# Altium schematic sheet LMcude-R_01.SchDoc(LMcude-R_01)
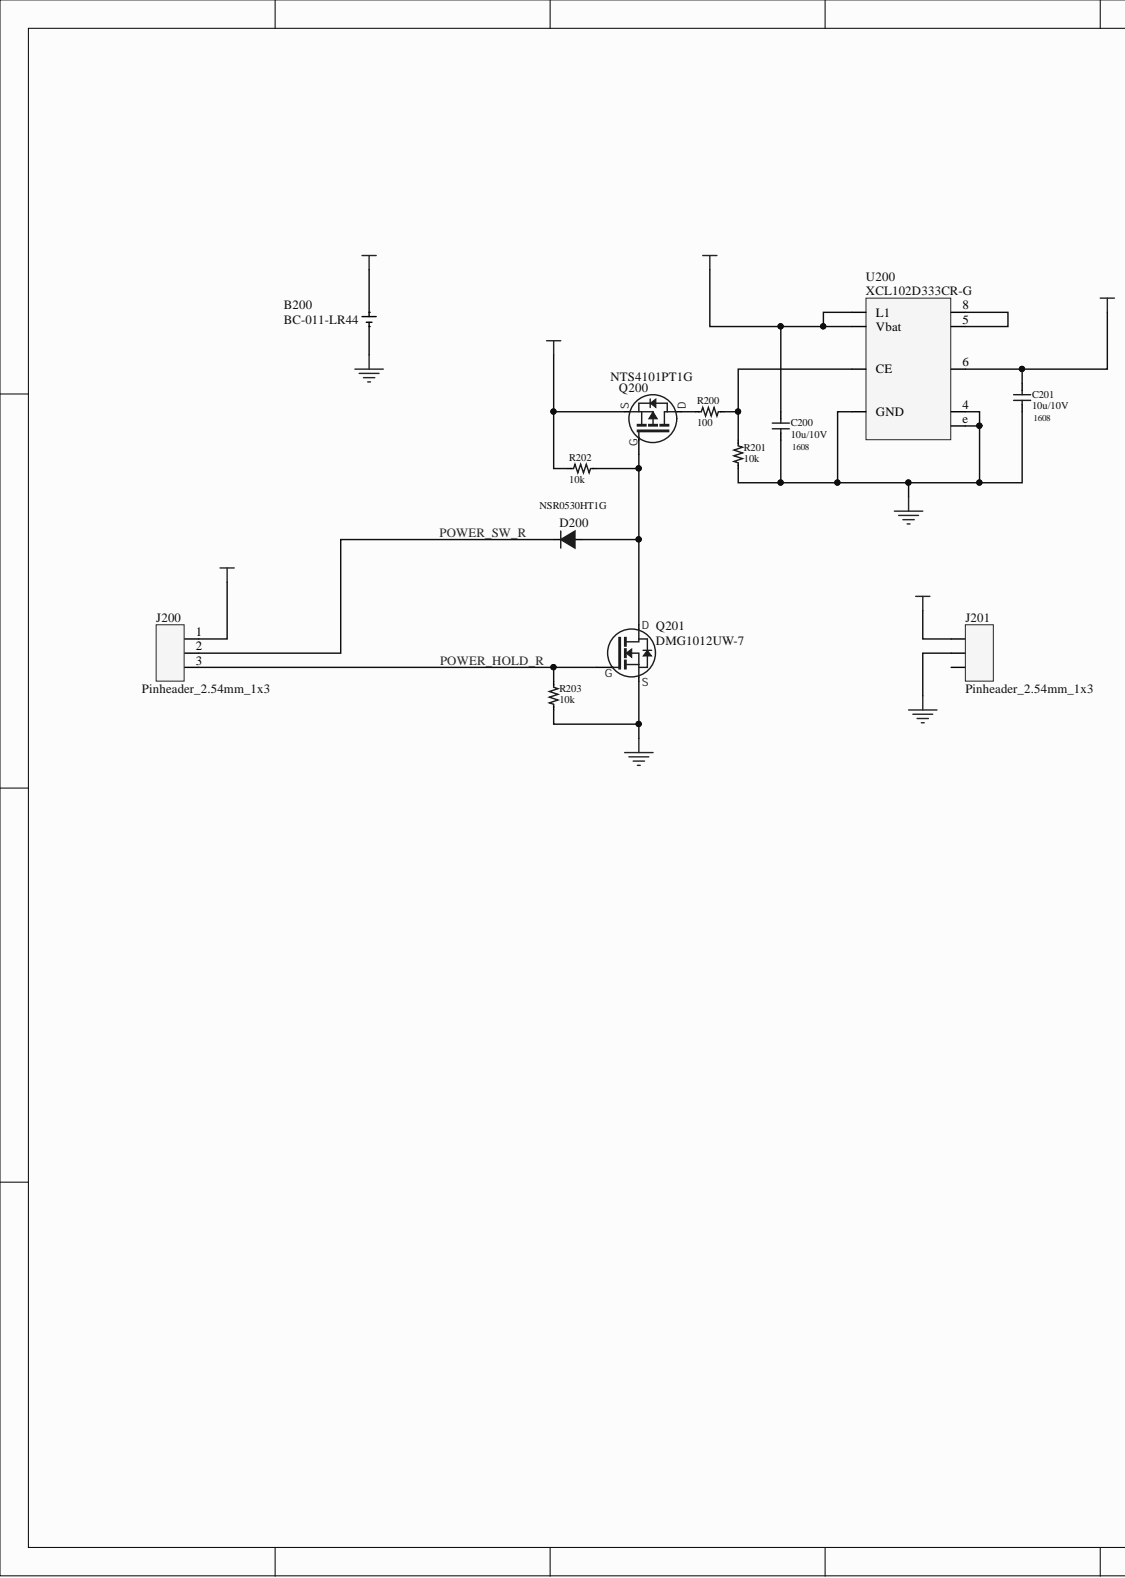
[diagram p0: LMcude-R_01.SchDoc, left]
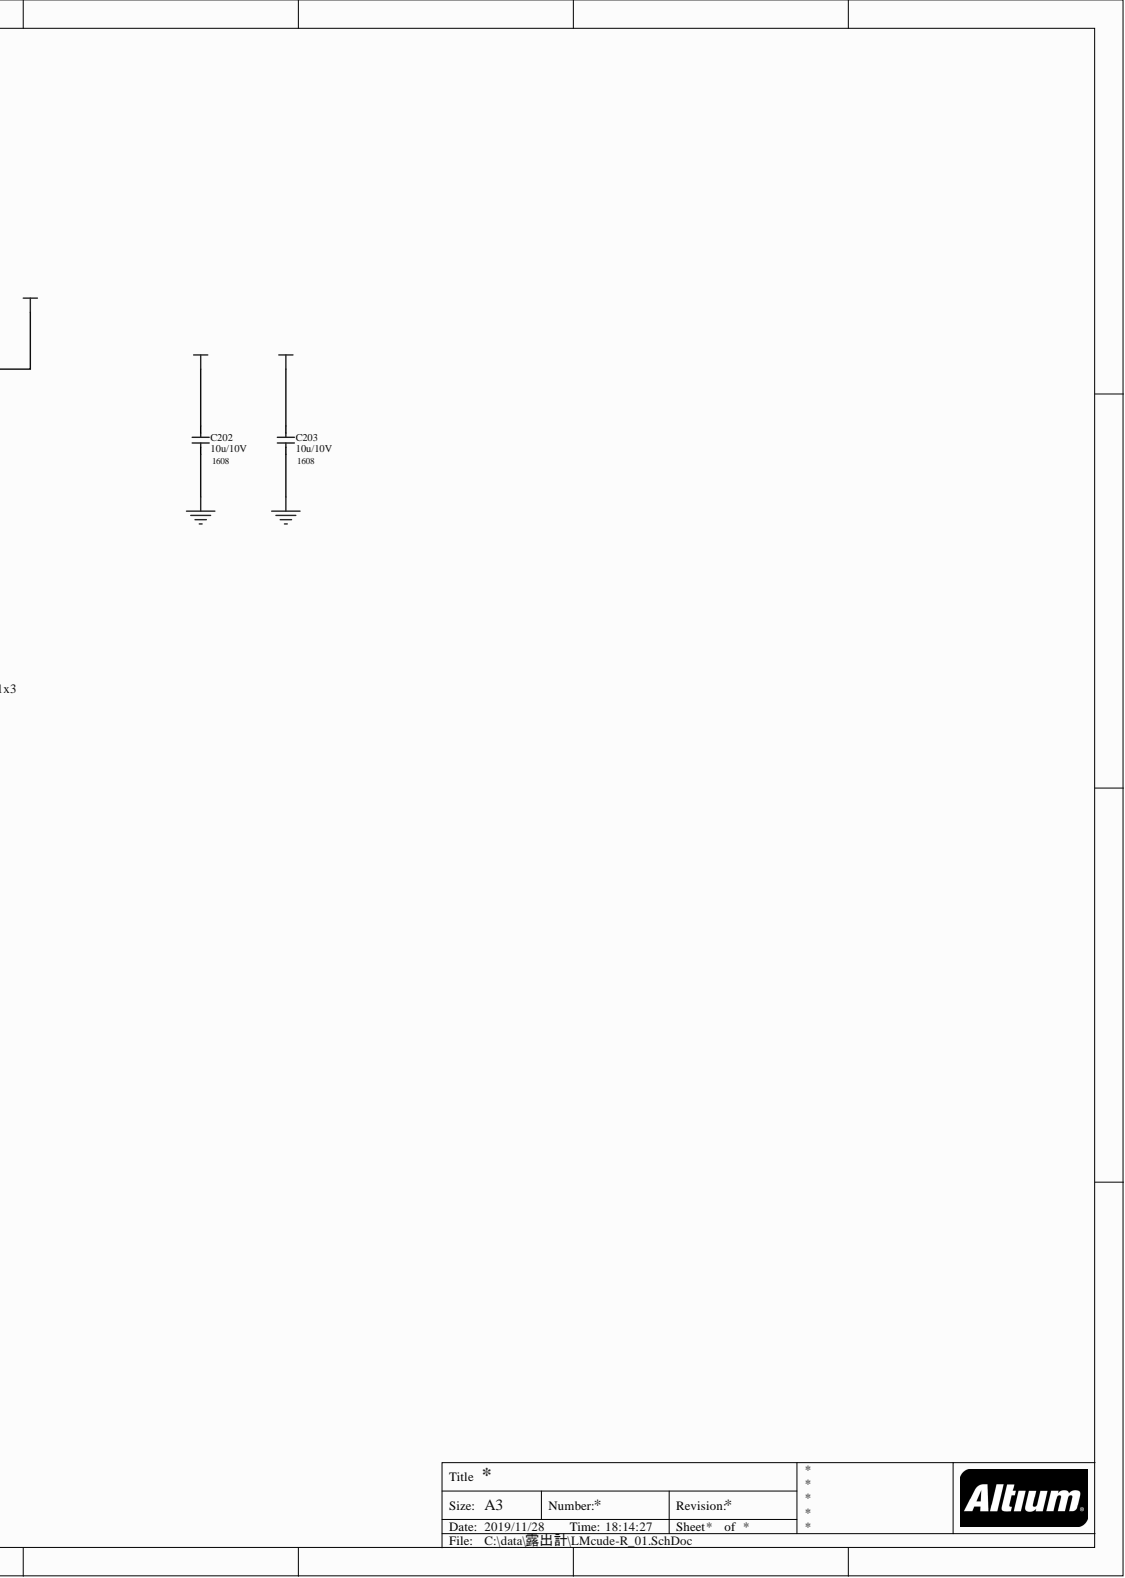
[diagram p1: LMcude-R_01.SchDoc, right]

## Parts
B200  value BC-011-LR44  [BC-011-LR44]  (hidden comment: Battery)
C200  10u/10V  Footprint(metric): 1608  [SC2-1.60X0.80A]
C201  10u/10V  Footprint(metric): 1608  [SC2-1.60X0.80A]
C202  10u/10V  Footprint(metric): 1608  [SC2-1.60X0.80A]
C203  10u/10V  Footprint(metric): 1608  [SC2-1.60X0.80A]
D200  NSR0530HT1G  [SOD-323 Onsemi]
J200  Pinheader_2.54mm_1x3  [Pinheader_2.54mm_1x3]
J201  Pinheader_2.54mm_1x3  [Pinheader_2.54mm_1x3]
Q200  NTS4101PT1G  [ON Semiconductor SC-70(SOT-323)]
Q201  DMG1012UW-7  [DMG1012UW-7]
R200  100  [SR2-1.00X0.50A]
R201  10k  [SR2-1.00X0.50A]
R202  10k  [SR2-1.00X0.50A]
R203  10k  [SR2-1.00X0.50A]
U200  XCL102D333CR-G  [TOREX CL-2025-02]

## Nets
3V3R: C201.1 C203.1 J201.1 U200.6
GND_R: B200.N C200.2 C201.2 C202.2 C203.2 J201.2 Q201.2 R201.2 R203.2 U200.2(GND) U200.4 U200.e
Vbat: B200.P C200.1 C202.1 J200.1 Q200.2 R202.2 U200.1(Vbat) U200.7(L1)
POWER_HOLD_R: J200.3 Q201.1 R203.1
POWER_SW_R: D200.C J200.2
NetD200_A: D200.A Q200.1 Q201.3 R202.1
NetQ200_3: Q200.3 R200.1
NetR200_2: R200.2 R201.1 U200.3(CE)
NetU200_5: U200.5 U200.8

Not connected: J201 3

## Library data of the parts
B200 = Battery: Manufacturer: COMFORTABLE ELECTRONIC CO., LTD; Sample Shop: AKIDUKI; Replace: ng; PCB P/N: LMcube; MP SPQ: unknown; Commodity: BATTERY HOLDER; BOM Rev: 0.00; Sample URL: http://akizukidenshi.com/catalog/g/gP-08408/; MP Price: unknown; MP MOQ: unknown; MP L/T(w): unknown; MP Dist.: unknown; Manufacturer Part Number: BC-011-LR44; Function Category: BATTERY; Component Kind: Standard; Library Name: I_Lib_cronos.IntLib
C200-C203 = C: CAP CER 4.7UF 16V X5R 0603; Manufacturer Part Number: LMK107BBJ106MALT; Manufacturer: Yuden; Sample Shop: Digikey; Replace: ok; PCB P/N: LMcube; MP SPQ: unknown; Function Category: Battery Charger; Commodity: MLCC; BOM Rev: 0.00; Value: 10u/10V; Sample URL: https://www.digikey.jp/product-detail/ja/taiyo-yuden/LMK107BBJ106MALT/587-3258-1-ND/3662218; MP Price: unknown; MP MOQ: unknown; MP L/T(w): unknown; MP Dist.: unknown; Footprint(imperial): 0603; Component Kind: Standard; Library Name: I_Lib_cronos.IntLib
D200 = NSR0530HT1G: Manufacturer: ONsemi; Sample Shop: Digikey; Replace: ng; PCB P/N: LMcube; MP SPQ: unknown; Function Category: Power Control; Commodity: Diode; BOM Rev: 0.00; Value: NSR0530HT1G; Sample URL: http://www.digikey.jp/product-detail/ja/on-semiconductor/NSR0530HT1G/NSR0530HT1GOSDKR-ND/5761699; MP Price: unknown; MP MOQ: unknown; MP L/T(w): unknown; MP Dist.: unknown; Manufacturer Part Number: NSR0530HT1G; Component Kind: Standard; Library Name: I_Lib_cronos.IntLib
J200 J201 = Con3: Manufacturer Part Number: 2.54mm Lowprofile Socket; Manufacturer: Neltron Industrial Co., Ltd.; Sample Shop: AKIDUKI; Replace: ng; PCB P/N: LMcube; MP SPQ: unknown; BOM Rev: 0.00; Value: Pinheader_2.54mm_1x3; Sample URL: http://akizukidenshi.com/catalog/g/gC-03138/; MP Price: unknown; MP MOQ: unknown; MP L/T(w): unknown; MP Dist.: unknown; Function Category: unknown; Component Kind: Standard; Commodity: unknown; Library Name: I_Lib_cronos.IntLib
Q200 = Pch_MOSFET: Sample Shop: Digikey; Replace: ng; PCB P/N: LMcube; MP SPQ: unknown; BOM Rev: 0.00; Sample URL: https://www.digikey.jp/product-detail/ja/on-semiconductor/NTS4101PT1G/NTS4101PT1GOSCT-ND/695259; MP Price: unknown; MP MOQ: unknown; MP L/T(w): unknown; MP Dist.: unknown; Manufacturer Part Number: NTS4101PT1G; Function Category: unknown; Component Kind: Standard; Commodity: Pch_MOSFET; Library Name: I_Lib_cronos.IntLib
Q201 = Nch_MOSFET: Manufacturer: Diodes Incorporated; Sample Shop: Digikey; Replace: ng; PCB P/N: LMcube; MP SPQ: unknown; Function Category: Power Control; BOM Rev: 0.00; Value: DMG1012UW-7; Sample URL: http://www.digikey.jp/product-detail/ja/diodes-incorporated/DMG1012UW-7/DMG1012UW-7DIDKR-ND/2183253; MP Price: unknown; MP MOQ: unknown; MP L/T(w): unknown; MP Dist.: unknown; Manufacturer Part Number: DMG1012UW-7; Component Kind: Standard; Commodity: Nch_MOSFET; Library Name: I_Lib_cronos.IntLib
R200 = R: RES_100_0402_1%_1/16W; Manufacturer Part Number: 100 1% 1/16W 1005metric; Manufacturer: Any; Sample Shop: Digikey; Replace: ok; PCB P/N: LMcube; MP SPQ: unknown; Function Category: Power Control; Commodity: Resistor; BOM Rev: 0.00; Value: 100; Sample URL: https://www.digikey.jp/product-detail/ja/yageo/RC0402FR-07100RL/311-100LRCT-ND/729474; MP Price: unknown; MP MOQ: unknown; MP L/T(w): unknown; MP Dist.: unknown; Footprint(metric): 1005; Footprint(imperial): 0402; Component Kind: Standard; Library Name: I_Lib_cronos.IntLib
R201-R203 = R: RES_10k_0402_1%_1/16W; Manufacturer Part Number: 10k 1% 1/16W 1005metric; Manufacturer: Any; Sample Shop: Digikey; Replace: ok; PCB P/N: LMcube; MP SPQ: unknown; Function Category: Power Control; Commodity: Resistor; BOM Rev: 0.00; Value: 10k; Sample URL: https://www.digikey.jp/product-detail/ja/yageo/RC0402FR-0710KL/311-10.0KLRCT-ND/729470; MP Price: unknown; MP MOQ: unknown; MP L/T(w): unknown; MP Dist.: unknown; Footprint(metric): 1005; Footprint(imperial): 0402; Component Kind: Standard; Library Name: I_Lib_cronos.IntLib
U200 = XCL102D333CR-G: Manufacturer: Torex Semiconductor Ltd; Sample Shop: Digikey; Replace: ng; PCB P/N: LMcube; MP SPQ: unknown; Function Category: Power Control; Commodity: DCDC; BOM Rev: 0.00; Value: XCL102D333CR-G; Sample URL: https://www.digikey.jp/product-detail/ja/torex-semiconductor-ltd/XCL102D333CR-G/893-1380-1-ND/7361193; MP Price: unknown; MP MOQ: unknown; MP L/T(w): unknown; MP Dist.: unknown; Manufacturer Part Number: XCL102D333CR-G; Component Kind: Standard; Library Name: I_Lib_cronos.IntLib
